# Altium schematic sheet P02_BB116_V2_JTAG, Clock, LED.SchDoc("P02_BB116_V2_JTAG, Clock, LED")
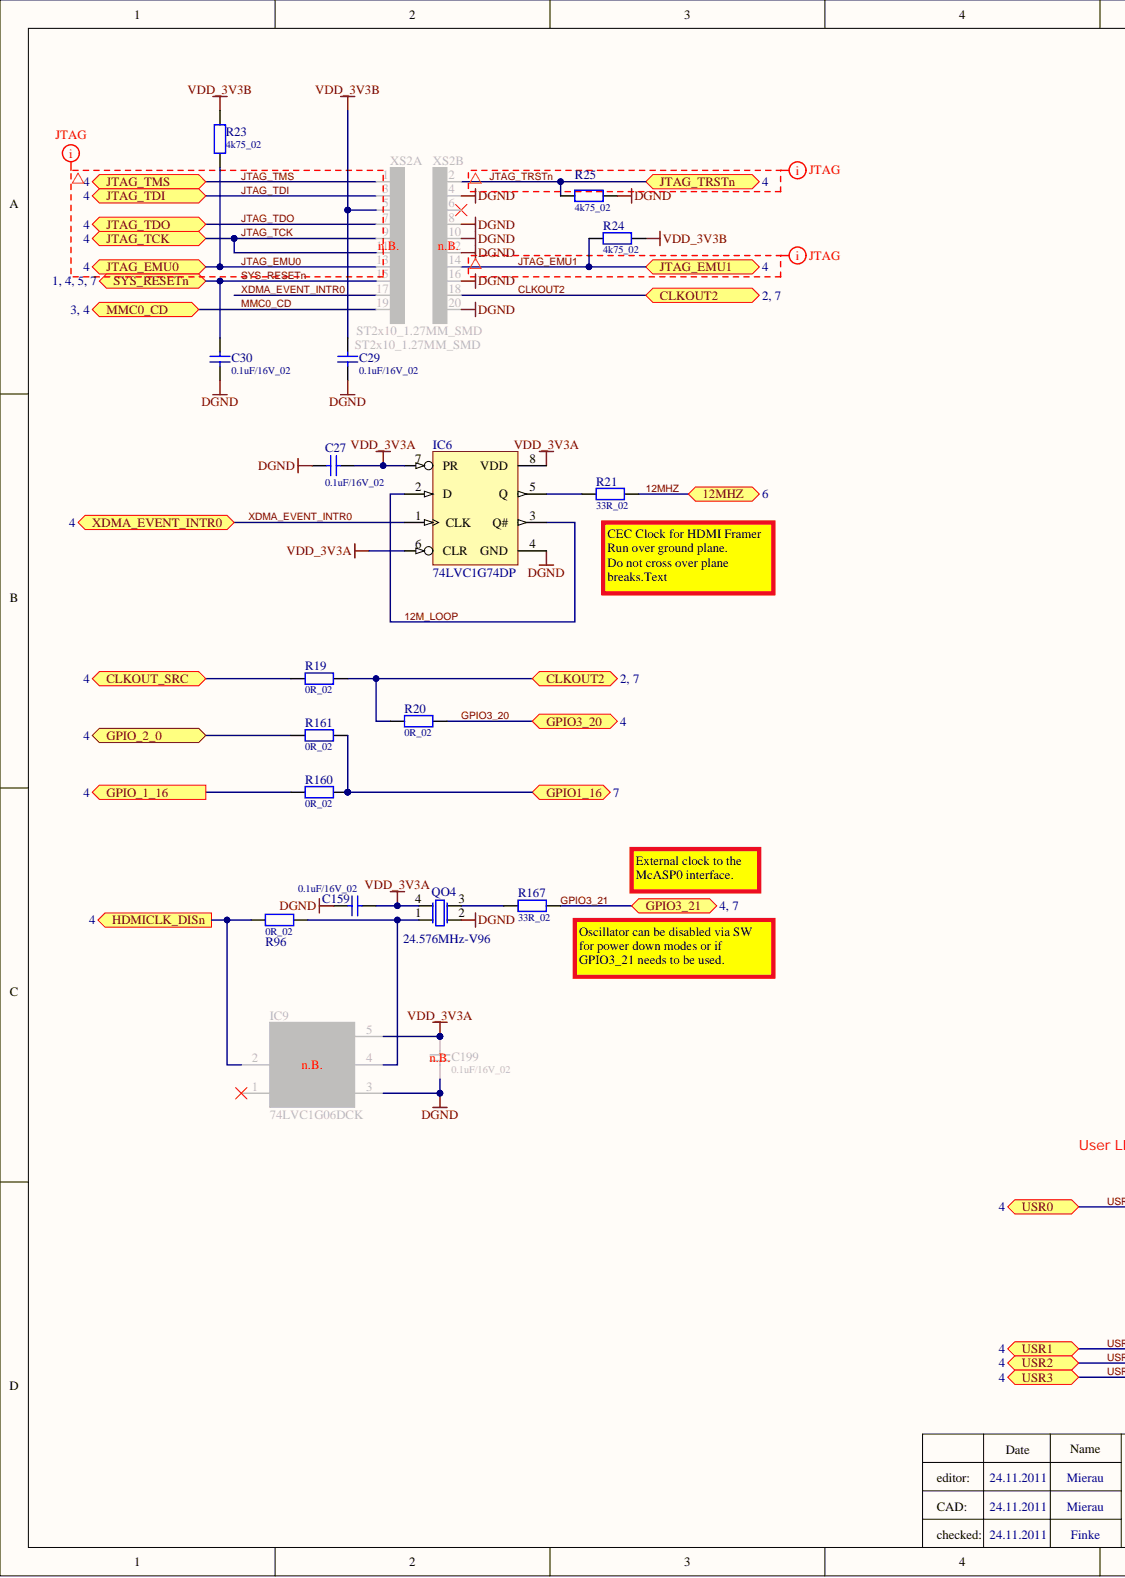
[diagram p0: P02_BB116_V2_JTAG, Clock, LED.SchDoc, left]
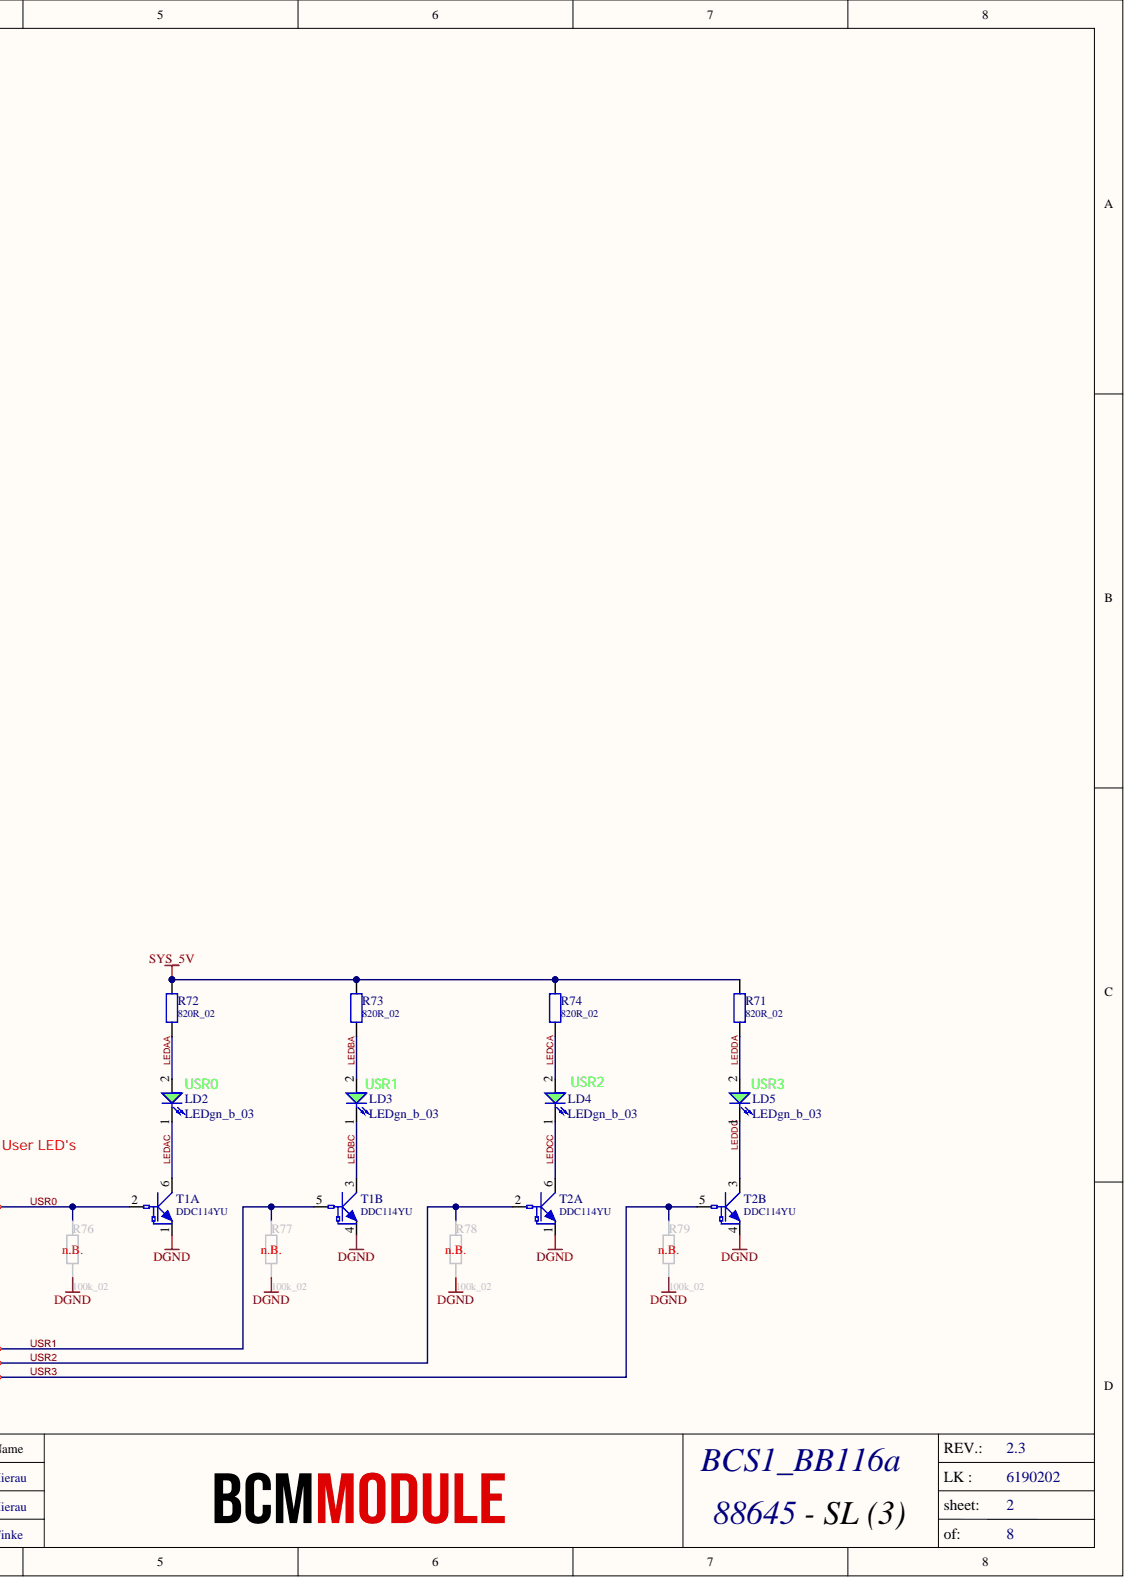
[diagram p1: P02_BB116_V2_JTAG, Clock, LED.SchDoc, right]

## Parts
C27  0.1uF/16V_02  [CC1005-0402]
C29  0.1uF/16V_02  [CC1005-0402]
C30  0.1uF/16V_02  [CC1005-0402]
C159  0.1uF/16V_02  [CC1005-0402]
C159
C199  0.1uF/16V_02  [CC1005-0402]
IC6  74LVC1G74DP  [TSSOP8 - 3x3]
IC9  74LVC1G06DCK  [SOT353]
LD2  LEDgn_b_03  [CD1608-0603]
LD3  LEDgn_b_03  [CD1608-0603]
LD4  LEDgn_b_03  [CD1608-0603]
LD5  LEDgn_b_03  [CD1608-0603]
QO4  24.576MHz-V96  [QUARZ KXO-V96]
R19  0R_02  [CR1005-0402]
R20  0R_02  [CR1005-0402]
R21  33R_02  [CR1005-0402]
R23  4k75_02  [CR1005-0402]
R24  4k75_02  [CR1005-0402]
R25  4k75_02  [CR1005-0402]
R71  820R_02  [CR1005-0402]
R72  820R_02  [CR1005-0402]
R73  820R_02  [CR1005-0402]
R74  820R_02  [CR1005-0402]
R76  100k_02  [CR1005-0402]
R77  100k_02  [CR1005-0402]
R78  100k_02  [CR1005-0402]
R79  100k_02  [CR1005-0402]
R96  0R_02  [CR1005-0402]
R160  0R_02  [CR1005-0402]
R161  0R_02  [CR1005-0402]
R167  33R_02  [CR1005-0402]
T1  DDC114YU  [SOT363]  (parts A,B)
T2  DDC114YU  [SOT363]  (parts A,B)
XS2  ST2x10_1.27MM_SMD  [ST2X10SMD-1.27_SAMTEC]  (parts A,B)

## Ports
12MHZ, CLKOUT2, CLKOUT_SRC, GPIO1_16, GPIO3_20, GPIO3_21, GPIO_1_16, GPIO_2_0, HDMICLK_DISN, JTAG_EMU0, JTAG_EMU1, JTAG_TCK, JTAG_TDI, JTAG_TDO, JTAG_TMS, JTAG_TRSTN, MMC0_CD, SYS_RESETN, USR0, USR1, USR2, USR3, XDMA_EVENT_INTR0

## Nets
DGND: C27.2 C29.1 C30.1 C159.1 C199.1 IC6.4 IC9.3 QO4.2 R25.2 R76.1 R77.1 R78.1 R79.1 T1.1 T1.4 T2.1 T2.4 XS2.4 XS2.8 XS2.10 XS2.12 XS2.16 XS2.20
HDMICLK_DISN: IC9.2 R96.1 ; port HDMICLK_DISN
SYS_5V: R71.1 R72.1 R73.1 R74.1
VDD_3V3A: C27.1 C159.2 C199.2 IC6.6 IC6.7 IC6.8 IC9.5 QO4.4
VDD_3V3B: C29.2 R23.1 R24.1 XS2.5
12M_LOOP: IC6.2 IC6.3
12MHZ: R21.2 ; port 12MHZ
CLKOUT2: R19.2 R20.1 XS2.18 ; port CLKOUT2
CLKOUT_SRC: R19.1 ; port CLKOUT_SRC
GPIO1_16: R160.2 R161.2 ; port GPIO1_16
GPIO3_20: R20.2 ; port GPIO3_20
GPIO3_21: R167.2 ; port GPIO3_21
GPIO_1_16: R160.1 ; port GPIO_1_16
GPIO_2_0: R161.1 ; port GPIO_2_0
JTAG_EMU0: R23.2 XS2.13 ; port JTAG_EMU0
JTAG_EMU1: R24.2 XS2.14 ; port JTAG_EMU1
JTAG_TCK: XS2.9 XS2.11 ; port JTAG_TCK
JTAG_TDI: XS2.3 ; port JTAG_TDI
JTAG_TDO: XS2.7 ; port JTAG_TDO
JTAG_TMS: XS2.1 ; port JTAG_TMS
JTAG_TRSTN: R25.1 XS2.2 ; port JTAG_TRSTN
LEDAA: LD2.2 R72.2
LEDAC: LD2.1 T1.6
LEDBA: LD3.2 R73.2
LEDBC: LD3.1 T1.3
LEDCA: LD4.2 R74.2
LEDCC: LD4.1 T2.6
LEDDA: LD5.2 R71.2
LEDDC: LD5.1 T2.3
MMC0_CD: XS2.19 ; port MMC0_CD
SYS_RESETN: C30.2 XS2.15 ; port SYS_RESETN
USR0: R76.2 T1.2 ; port USR0
USR1: R77.2 T1.5 ; port USR1
USR2: R78.2 T2.2 ; port USR2
USR3: R79.2 T2.5 ; port USR3
XDMA_EVENT_INTR0: IC6.1 XS2.17 ; port XDMA_EVENT_INTR0
NetIC6_5: IC6.5 R21.1
NetIC9_4: IC9.4 QO4.1 R96.2
NetQO4_3: QO4.3 R167.1
N00042:
N00043:
N00044:

Not connected: IC9 1; XS2 6

## Library data of the parts
C27 C29 C30 C159 C199 = C0.1uF/16V_02: Capacitor 0402 16V Y5V; Art-Nr.: 08.0002.0001; Bestellbezeichnung1: MCCA000051; Hersteller1: Multicomp; S/T: SMD; Component Kind: Standard; Value: 0.1uF/16V_02; Library Name: C_ies_Integrated_Library.IntLib
IC6 = IC74LVC1G74DP: Dual D-Type Positive-Edge-Triggered Flip-Flop with Set and Reset; Art-Nr.: 04.0501.00__; erstellt von: Finke; Hersteller: NXP; Lieferant: Arrow; S/T: SMD; Bestellbezeichnung: 74LVC1G74DP; Component Kind: Standard; ComponentLink1Description: Datenblatt 74LVC1G74DP; Value: 74LVC1G74DP; ComponentLink1URL: u:\IC_Integrierte Bauteile\philips_NXP\74LVC1G74.pdf; Library Name: IC_ies_Integrated_Library.IntLib
IC9 = IC74LVC1G06DCK: Single Inverter Buffer/Driver With Open-Drain Output; Art-Nr.: 04.0501.; erstellt von: MIE; Hersteller: TI; Lieferant: Arrow; S/T: SMD; Bestellbezeichnung: 74LVC1G06DCK; Component Kind: Standard; ComponentLink1Description: Datenblatt; Value: 74LVC1G06DCK; ComponentLink1URL: U:\IC_Integrierte Bauteile\ti\sn74lvc1g06.pdf; Library Name: IC_ies_Integrated_Library.IntLib
LD2-LD5 = LD_LEDgn_bright_03: Leuchtdiode bright grün 0603, RV(5mA)@3V3= 100OHM; Art-Nr.: 05.0401.0022; Bestellbezeichnung: 150060VS75000; Hersteller: Würth Elektronik; S/T: SMD; Component Kind: Standard; ComponentLink1Description: LEDge_03 Datenblatt; Lieferant: Würth Elektronik; Value: LEDgn_b_03; ComponentLink1URL: u:\LD_Leuchtdioden\Wuerth\150060VS75000.pdf; Library Name: LD_ies_Integrated_Library.IntLib
QO4 = QO24.576MHz_V96: Quarz Oszillator 24.576MHz; KXO-V96; Art-Nr.: 12.0601.; Bestellbezeichnung: KXO-V96 24.576MHz; Hersteller: Geyer; S/T: SMD; Component Kind: Standard; ComponentLink1Description: Datenblatt; Lieferant: Geyer; Value: 24.576MHz-V96; ComponentLink1URL: u:\Q_Quarz\Geyer\GEYER-KXO-V96_02.pdf; Library Name: Q_ies_Integrated_Library.IntLib
R19 R20 R96 R160 R161 = R0R_02: Resistor 0402; Art-Nr.: 09.0002.0002; S/T: SMD; Component Kind: Standard; Value: 0R_02; Library Name: R_ies_Integrated_Library.IntLib
R21 R167 = R33R_02: Resistor 0402; Art-Nr.: 09.0002.0014; S/T: SMD; Component Kind: Standard; Value: 33R_02; Library Name: R_ies_Integrated_Library.IntLib
R23-R25 = R4k75_02: Resistor 0402; Art-Nr.: 09.0002.0017; S/T: SMD; Component Kind: Standard; Value: 4k75_02; Library Name: R_ies_Integrated_Library.IntLib
R71-R74 = R820R_02: Resistor 0402; Art-Nr.: 09.0002.; S/T: SMD; Component Kind: Standard; Value: 820R_02; Library Name: R_ies_Integrated_Library.IntLib
R76-R79 = R100k_02: Resistor 0402; Art-Nr.: 09.0002.0003; S/T: SMD; Component Kind: Standard; Value: 100k_02; Library Name: R_ies_Integrated_Library.IntLib
T1 T2 = TDDC114YU: 2 fach NPN m Widerständen Vceo=40V, Ic=0.1A; Art-Nr.: 06.0001.00__; erstellt von: Finke; Hersteller: Rohm; S/T: SMD; Bestellbezeichnung: DDC114YU; Component Kind: Standard; ComponentLink1Description: Datenblatt; Value: DDC114YU; ComponentLink1URL: u:\T_Transistoren\diodes\ds30345.pdf; Library Name: T_ies_Integrated_Library.IntLib
XS2 = XST2X10_1.27MM_SMD_SAM: Stiftleiste 20polig, 1.27mm pitch, Samtec; Art-Nr.: 03.0102.00__; Bestellbezeichnung: FTR-110-03-G-D-06; S/T: SMD; Component Kind: Standard; ComponentLink1Description: Datenblatt; Hersteller: Samtec; Value: ST2x10_1.27MM_SMD; Library Name: X_ies_Integrated_Library.IntLib
